# Altium schematic sheet Top.SchDoc
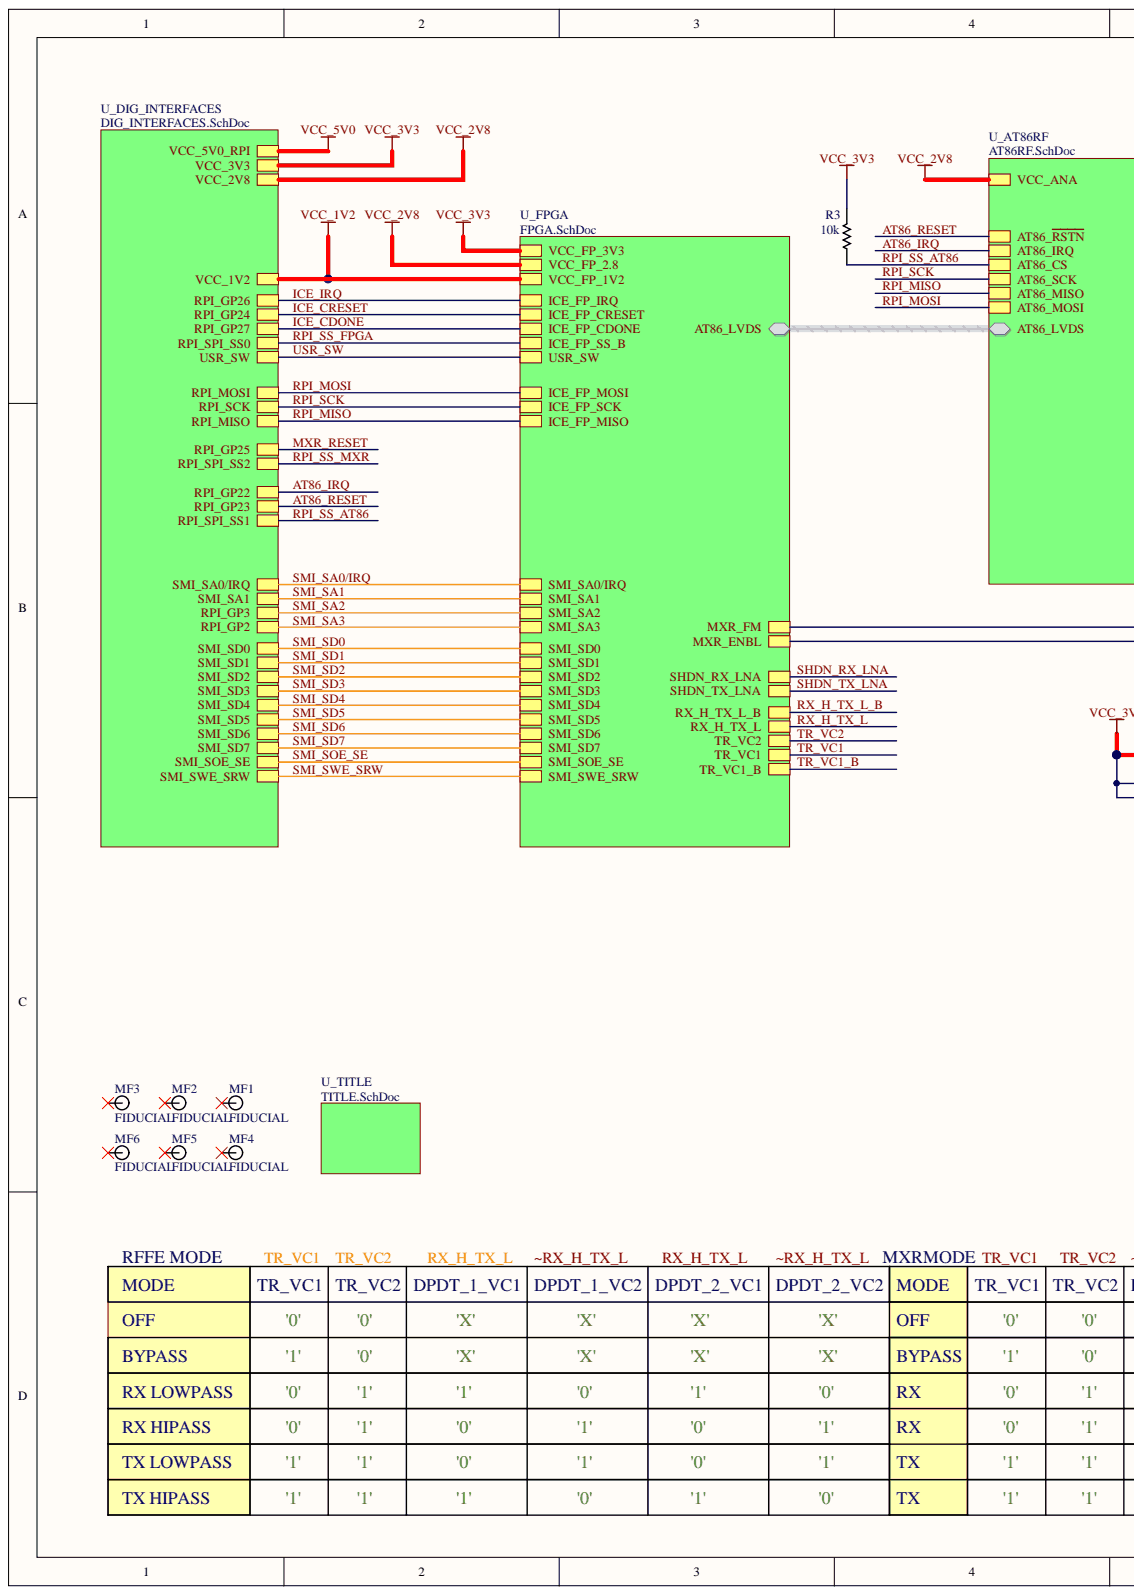
[diagram p0: Top.SchDoc, left]
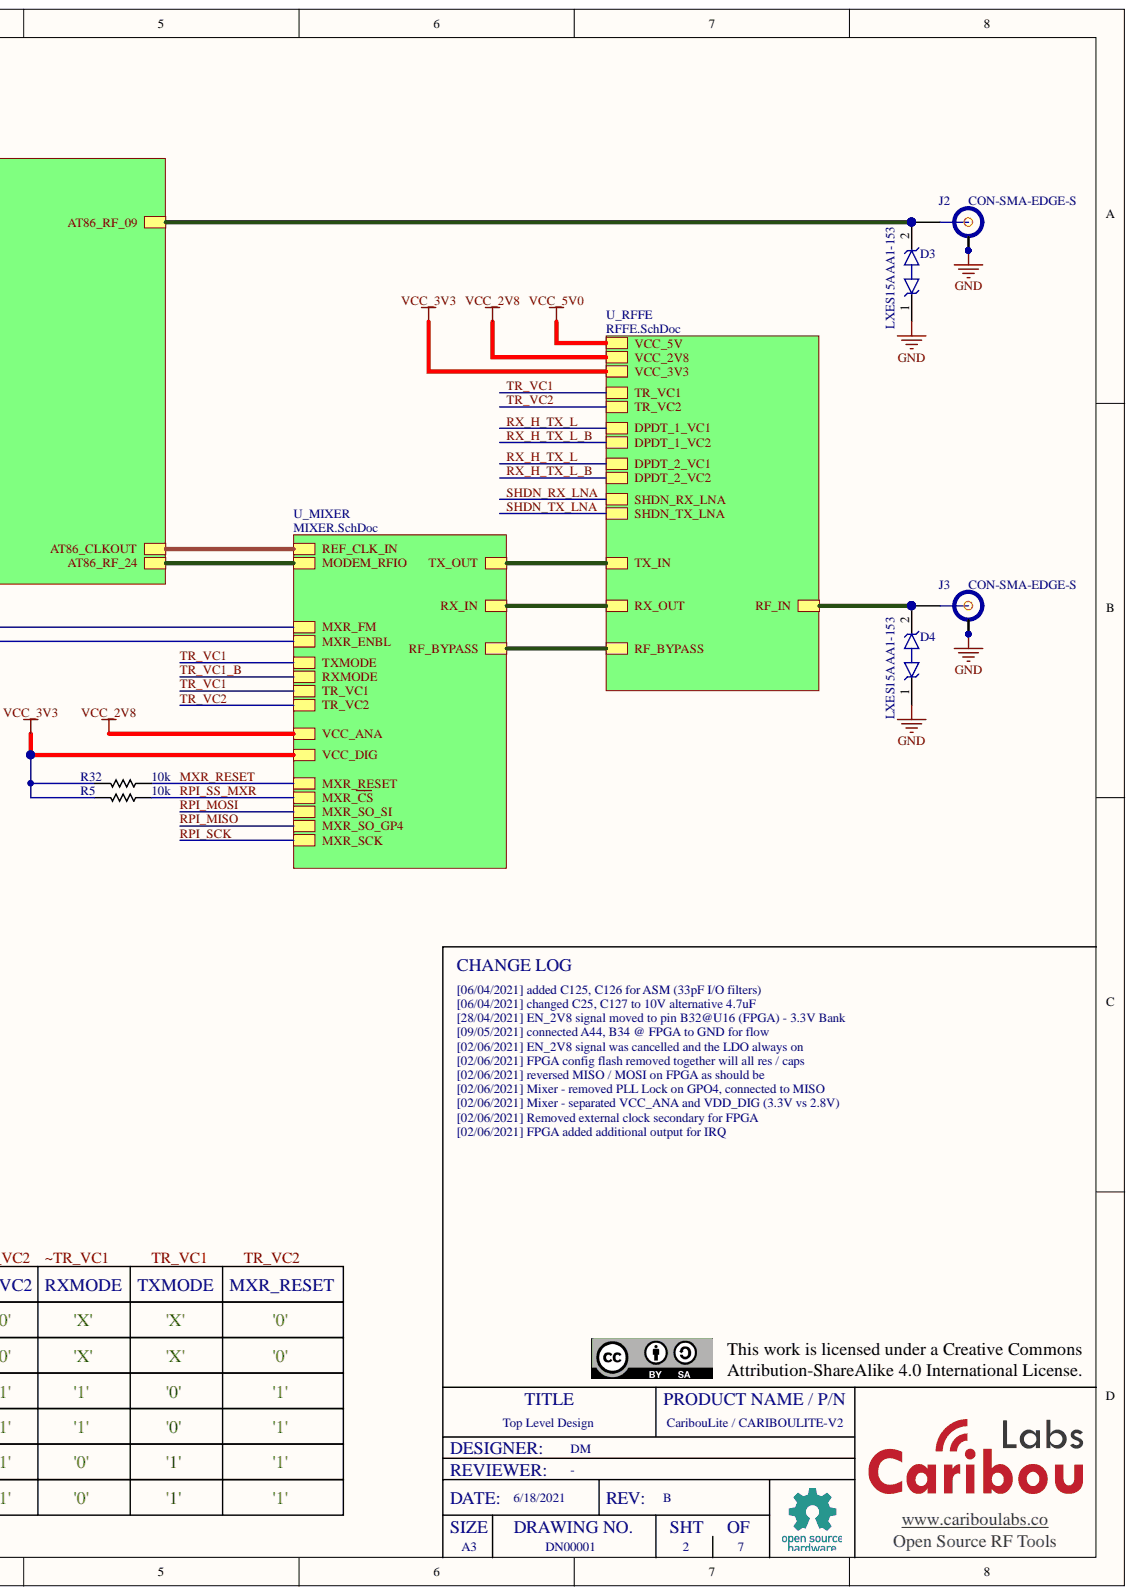
[diagram p1: Top.SchDoc, right]

## Parts
D3  LXES15AAA1-153  [DIONC1105X44N]
D4  LXES15AAA1-153  [DIONC1105X44N]
J2  CON-SMA-EDGE-S  [MOLEX_0732511150]
J3  CON-SMA-EDGE-S  [MOLEX_0732511150]
MF1  FIDUCIAL  [FIDUCIAL_1_0_MM]
MF2  FIDUCIAL  [FIDUCIAL_1_0_MM]
MF3  FIDUCIAL  [FIDUCIAL_1_0_MM]
MF4  FIDUCIAL  [FIDUCIAL_1_0_MM]
MF5  FIDUCIAL  [FIDUCIAL_1_0_MM]
MF6  FIDUCIAL  [FIDUCIAL_1_0_MM]
R3  10k  [PANASONIC 0402]
R5  10k  [PANASONIC 0402]
R32  10k  [PANASONIC 0402]

## Nets
GND: D3.1 D4.1 J2.2 J2.3 J2.4 J2.5 J2.6 J2.7 J3.2 J3.3 J3.4 J3.5 J3.6 J3.7
VCC_3V3: R3.2 R5.2 R32.2
MXR_RESET: R32.1
RPI_SS_AT86: R3.1
RPI_SS_MXR: R5.1
NetD3_2: D3.2 J2.1
NetD4_2: D4.2 J3.1
NetMF1_1: MF1.1
NetMF2_1: MF2.1
NetMF3_1: MF3.1
NetMF4_1: MF4.1
NetMF5_1: MF5.1
NetMF6_1: MF6.1
AT86_CLKOUT:
AT86_IRQ:
AT86_LVDS.AT86_RX09_N:
AT86_LVDS.AT86_RX09_P:
AT86_LVDS.AT86_RX24_N:
AT86_LVDS.AT86_RX24_P:
AT86_LVDS.AT86_RX_CLK_N:
AT86_LVDS.AT86_RX_CLK_P:
AT86_LVDS.AT86_TX_CLK_N:
AT86_LVDS.AT86_TX_CLK_P:
AT86_LVDS.AT86_TX_N:
AT86_LVDS.AT86_TX_P:
AT86_RESET:
AT86_RF_24:
ICE_CDONE:
ICE_CRESET:
ICE_IRQ:
MXR_ENBL:
MXR_FM:
RF_BYPASS:
RPI_MISO:
RPI_MOSI:
RPI_SCK:
RPI_SS_FPGA:
RX_H_TX_L:
RX_H_TX_L_B:
RX_IN:
SHDN_RX_LNA:
SHDN_TX_LNA:
SMI_SA0/IRQ:
SMI_SA1:
SMI_SA2:
SMI_SA3:
SMI_SD0:
SMI_SD1:
SMI_SD2:
SMI_SD3:
SMI_SD4:
SMI_SD5:
SMI_SD6:
SMI_SD7:
SMI_SOE_SE:
SMI_SWE_SRW:
TR_VC1:
TR_VC1_B:
TR_VC2:
TX_OUT:
USR_SW:
VCC_1V2:
VCC_2V8:
VCC_5V0:

## Library data of the parts
D3 D4 = LXES15AAA1-153: ESD Suppressors / TVS Diodes ESD Protection Device, 0402, Bidirectional, 4V working, 15V breakdown, 0.05pF Cd; Component Kind: Standard; Library Name: CuSiP_Lib.IntLib; Manu. P/N: LXES15AAA1-153
J2 J3 = CON-SMA-EDGE-S: RF SMA Connectors / Coaxial Connectors SMA Female PCB Edge Mount; Component Kind: Standard; Library Name: DM_Lib.IntLib; Manu. P/N: CON-SMA-EDGE-S
R3 R5 R32 = Resistor: Thick Film Resistors - SMD 0402 1/16watt 10Kohms 1%; Manu. P/N: CRCW040210K0FKEDC; Power Rating: 62.5 mW (1/16 W); Temp. Ceoff.: 100 PPM/C; Component Kind: Standard; Library Name: CuSiP_Lib.IntLib; Simulation: Model Name; Tolerance: 1%; VALUE: 10k
MF1-MF6 = FIDUCIAL: Component Kind: Net Tie; Library Name: CuSiP_Lib.IntLib; MANU_PN: FIDUCIAL
